# Altium schematic sheet PIN(1~2).SchDoc(PIN(1~2))
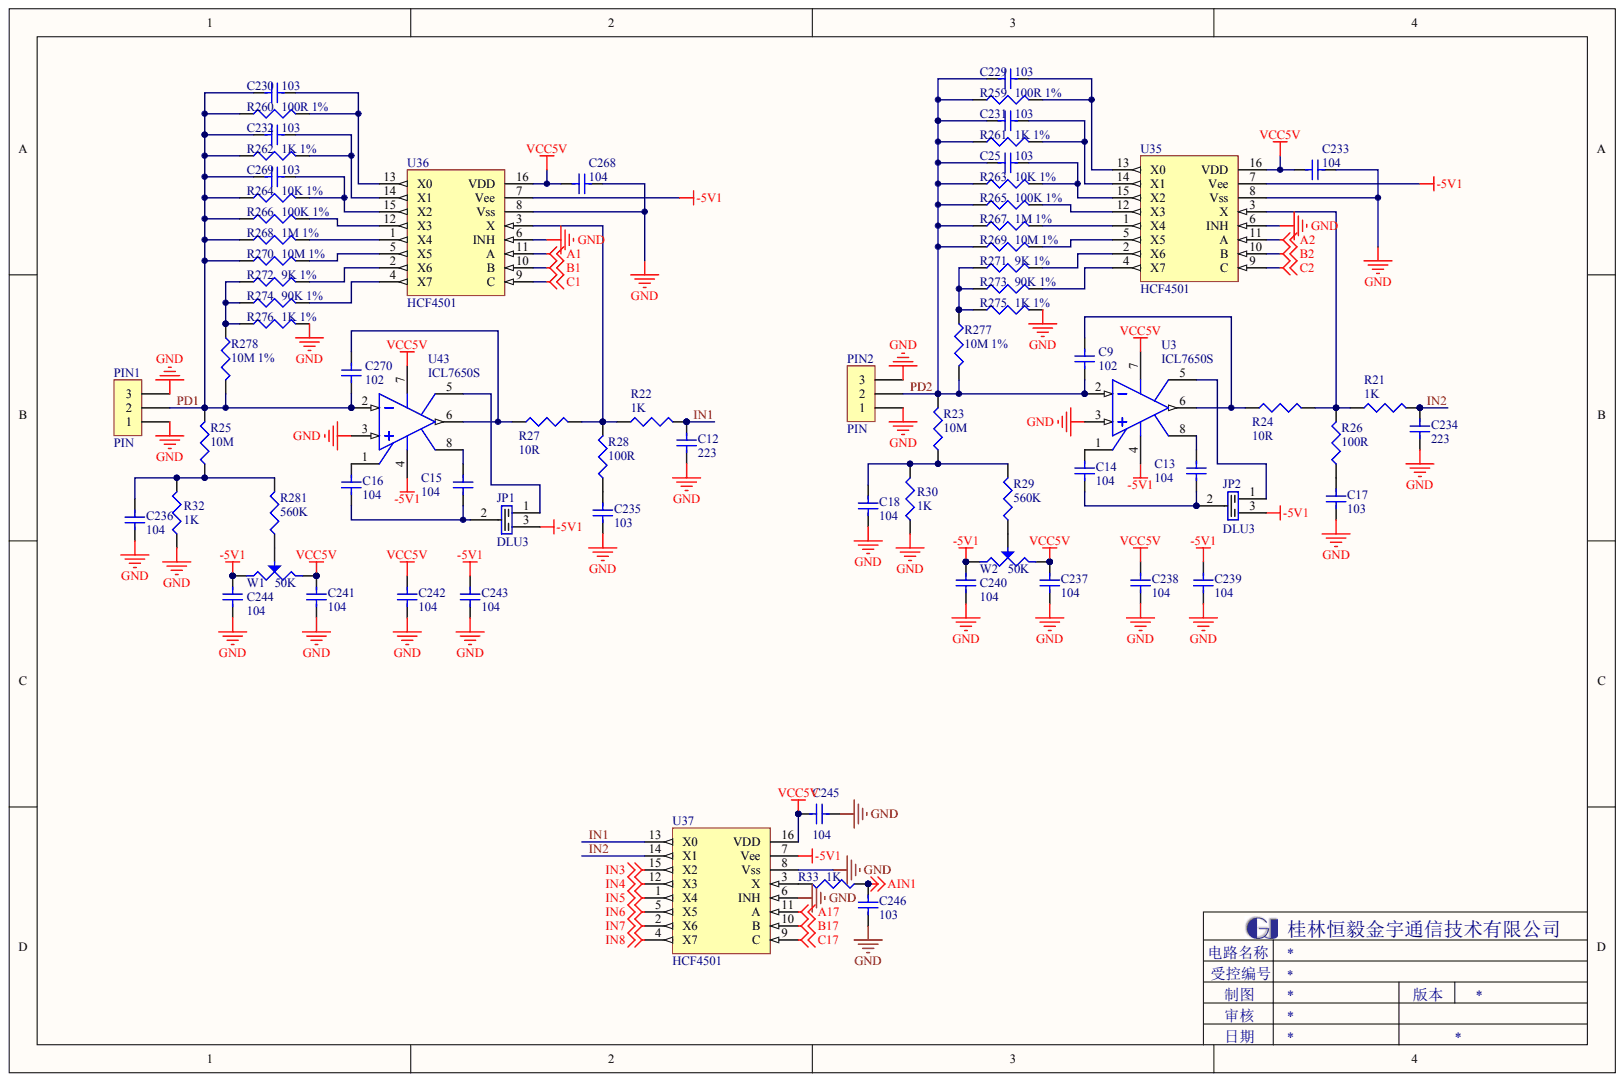
[diagram p0: PIN]

## Parts
C9  102
C12  223
C13  104
C14  104
C15  104
C16  104
C17  103
C18  104
C25  103
C229  103
C230  103
C231  103
C232  103
C233  104
C234  223
C235  103
C236  104
C237  104
C238  104
C239  104
C240  104
C241  104
C242  104
C243  104
C244  104
C245  104
C246  103
C268  104
C269  103
C270  102
JP1  DLU3
JP2  DLU3
PIN1  PIN
PIN2  PIN
R21  1K
R22  1K
R23  10M
R24  10R
R25  10M
R26  100R
R27  10R
R28  100R
R29  560K
R30  1K
R32  1K
R33  1K
R259  100R 1%
R260  100R 1%
R261  1K 1%
R262  1K 1%
R263  10K 1%
R264  10K 1%
R265  100K 1%
R266  100K 1%
R267  1M 1%
R268  1M 1%
R269  10M 1%
R270  10M 1%
R271  9K 1%
R272  9K 1%
R273  90K 1%
R274  90K 1%
R275  1K 1%
R276  1K 1%
R277  10M 1%
R278  10M 1%
R281  560K
U3  ICL7650S
U35  HCF4501
U36  HCF4501
U37  HCF4501
U43  ICL7650S
W1  50K
W2  50K

## Nets
-5V1: C239.2 C240.1 C243.2 C244.1 JP1.3 JP2.3 U3.4 U35.7(Vee) U36.7(Vee) U37.7(Vee) U43.4 W1.1 W2.1
A1: U36.11(A)
A2: U35.11(A)
A17: U37.11(A)
AIN1: C246.2 R33.1
B1: U36.10(B)
B2: U35.10(B)
B17: U37.10(B)
C1: U36.9(C)
C2: U35.9(C)
C17: U37.9(C)
GND: C12.1 C17.1 C18.1 C233.2 C234.1 C235.1 C236.1 C237.1 C238.1 C239.1 C240.2 C241.1 C242.1 C243.1 C244.2 C245.1 C246.1 C268.2 PIN1.1 PIN1.3 PIN2.1 PIN2.3 R30.1 R32.1 R275.2 R276.2 U3.3 U35.6(INH) U35.8(Vss) U36.6(INH) U36.8(Vss) U37.6(INH) U37.8(Vss) U43.3
IN3: U37.15(X2)
IN4: U37.12(X3)
IN5: U37.1(X4)
IN6: U37.5(X5)
IN7: U37.2(X6)
IN8: U37.4(X7)
VCC5V: C233.1 C237.2 C238.2 C241.2 C242.2 C245.2 C268.1 U3.7 U35.16(VDD) U36.16(VDD) U37.16(VDD) U43.7 W1.3 W2.3
IN1: C12.2 R22.2 U37.13(X0)
IN2: C234.2 R21.2 U37.14(X1)
PD1: C230.1 C232.1 C269.1 C270.1 PIN1.2 R25.2 R260.1 R262.1 R264.1 R266.1 R268.1 R270.1 R278.2 U43.2
PD2: C9.1 C25.1 C229.1 C231.1 PIN2.2 R23.2 R259.1 R261.1 R263.1 R265.1 R267.1 R269.1 R277.2 U3.2
NetC9_2: C9.2 R24.1 U3.6
NetC13_1: C13.1 U3.8
NetC13_2: C13.2 C14.1 JP2.2
NetC14_2: C14.2 U3.1
NetC15_1: C15.1 U43.8
NetC15_2: C15.2 C16.1 JP1.2
NetC16_2: C16.2 U43.1
NetC17_2: C17.2 R26.1
NetC18_2: C18.2 R23.1 R29.2 R30.2
NetC25_2: C25.2 R263.2 U35.15(X2)
NetC229_2: C229.2 R259.2 U35.13(X0)
NetC230_2: C230.2 R260.2 U36.13(X0)
NetC231_2: C231.2 R261.2 U35.14(X1)
NetC232_2: C232.2 R262.2 U36.14(X1)
NetC235_2: C235.2 R28.1
NetC236_2: C236.2 R25.1 R32.2 R281.2
NetC269_2: C269.2 R264.2 U36.15(X2)
NetC270_2: C270.2 R27.1 U43.6
NetJP1_1: JP1.1 U43.5
NetJP2_1: JP2.1 U3.5
NetR21_1: R21.1 R24.2 R26.2 U35.3(X)
NetR22_1: R22.1 R27.2 R28.2 U36.3(X)
NetR29_1: R29.1 W2.2
NetR33_2: R33.2 U37.3(X)
NetR265_2: R265.2 U35.12(X3)
NetR266_2: R266.2 U36.12(X3)
NetR267_2: R267.2 U35.1(X4)
NetR268_2: R268.2 U36.1(X4)
NetR269_2: R269.2 U35.5(X5)
NetR270_2: R270.2 U36.5(X5)
NetR271_1: R271.1 R273.1 R275.1 R277.1
NetR271_2: R271.2 U35.2(X6)
NetR272_1: R272.1 R274.1 R276.1 R278.1
NetR272_2: R272.2 U36.2(X6)
NetR273_2: R273.2 U35.4(X7)
NetR274_2: R274.2 U36.4(X7)
NetR281_1: R281.1 W1.2
